# Altium schematic sheet MCU.SchDoc(MCU)
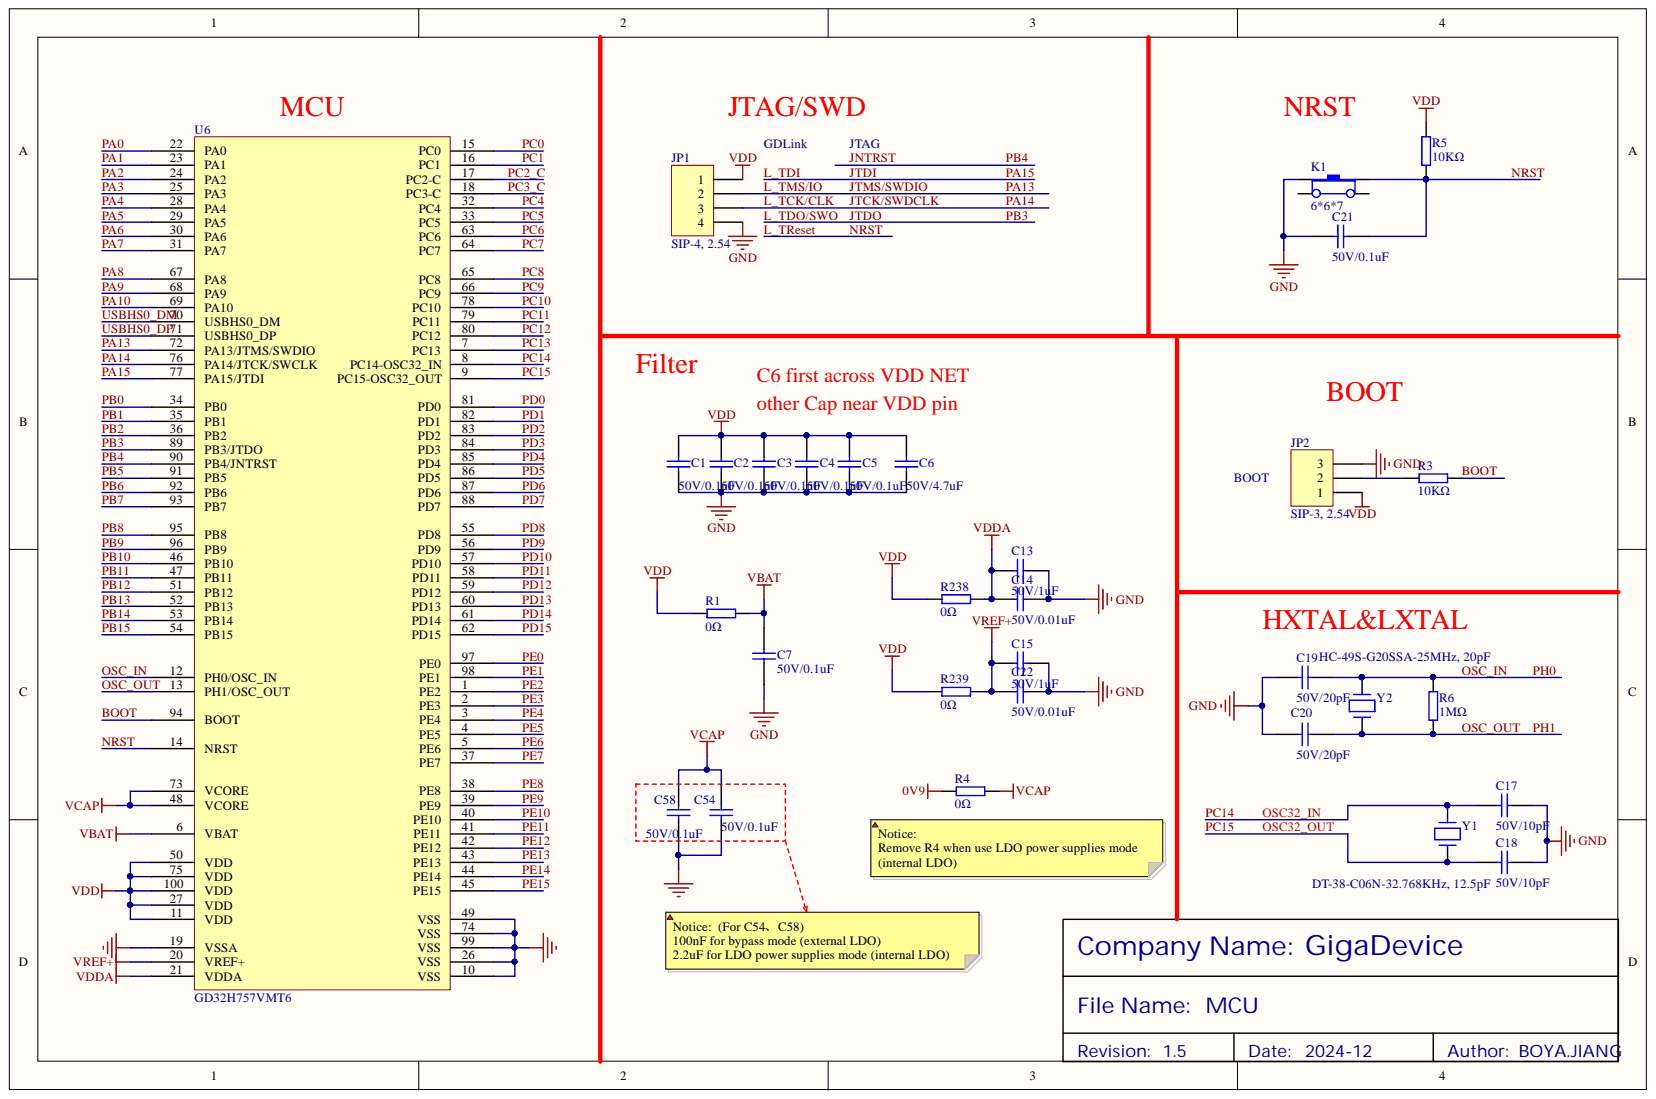
[diagram p0: MCU.SchDoc]

## Parts
C1  50V/0.1uF  [0603-C]
C2  50V/0.1uF  [0603-C]
C3  50V/0.1uF  [0603-C]
C4  50V/0.1uF  [0603-C]
C5  50V/0.1uF  [0603-C]
C6  50V/4.7uF  [0603-C]
C7  50V/0.1uF  [0603-C]
C13  50V/1uF  [0603-C]
C14  50V/0.01uF  [0603-C]
C15  50V/1uF  [0603-C]
C17  50V/10pF  [0603-C]
C18  50V/10pF  [0603-C]
C19  50V/20pF  [0603-C]
C20  50V/20pF  [0603-C]
C21  50V/0.1uF  [0603-C]
C22  50V/0.01uF  [0603-C]
C54  50V/0.1uF  [0603-C]
C58  50V/0.1uF  [0603-C]
JP1  SIP-4, 2.54  [SIP-4]
JP2  SIP-3, 2.54  [SIP-3]
K1  6*6*7  [K-1102B]
R1  0Ω  [0805-R]
R3  10KΩ  [0603-R]
R4  0Ω  [0805-R]
R5  10KΩ  [0603-R]
R6  1MΩ  [0603-R]
R238  0Ω  [0805-R]
R239  0Ω  [0805-R]
U6  GD32H757VMT6  [LQFP100_14x14x1.6]
Y1  DT-38-C06N-32.768KHz, 12.5pF  [DT-26]
Y2  HC-49S-G20SSA-25MHz, 20pF  [HC-49S]

## Nets
0V9: R4.1
GND: C1.1 C2.1 C3.1 C4.1 C5.1 C6.1 C7.2 C13.1 C14.1 C15.1 C17.2 C18.1 C19.1 C20.1 C21.2 C22.1 C54.2 C58.2 JP1.4 JP2.3 K1.1 U6.10(VSS) U6.19(VSSA) U6.26(VSS) U6.49(VSS) U6.74(VSS) U6.99(VSS)
VBAT: C7.1 R1.2 U6.6(VBAT)
VCAP: C54.1 C58.1 R4.2 U6.48(VCORE) U6.73(VCORE)
VDD: C1.2 C2.2 C3.2 C4.2 C5.2 C6.2 JP1.1 JP2.1 R1.1 R5.2 R238.1 R239.1 U6.11(VDD) U6.27(VDD) U6.50(VDD) U6.75(VDD) U6.100(VDD)
VDDA: C13.2 C14.2 R238.2 U6.21(VDDA)
VREF+: C15.2 C22.2 R239.2 U6.20(VREF+)
BOOT: R3.1 U6.94(BOOT)
JNTRST: U6.90(PB4/JNTRST)
JTCK/SWDCLK: JP1.3 U6.76(PA14/JTCK/SWCLK)
JTDI: U6.77(PA15/JTDI)
JTDO: U6.89(PB3/JTDO)
JTMS/SWDIO: JP1.2 U6.72(PA13/JTMS/SWDIO)
L_TReset: C21.1 K1.3 R5.1 U6.14(NRST)
OSC_IN: C19.2 R6.2 U6.12(PH0/OSC_IN) Y2.2
OSC_OUT: C20.2 R6.1 U6.13(PH1/OSC_OUT) Y2.1
PA0: U6.22(PA0)
PA1: U6.23(PA1)
PA2: U6.24(PA2)
PA3: U6.25(PA3)
PA4: U6.28(PA4)
PA5: U6.29(PA5)
PA6: U6.30(PA6)
PA7: U6.31(PA7)
PA8: U6.67(PA8)
PA9: U6.68(PA9)
PA10: U6.69(PA10)
PB0: U6.34(PB0)
PB1: U6.35(PB1)
PB2: U6.36(PB2)
PB5: U6.91(PB5)
PB6: U6.92(PB6)
PB7: U6.93(PB7)
PB8: U6.95(PB8)
PB9: U6.96(PB9)
PB10: U6.46(PB10)
PB11: U6.47(PB11)
PB12: U6.51(PB12)
PB13: U6.52(PB13)
PB14: U6.53(PB14)
PB15: U6.54(PB15)
PC0: U6.15(PC0)
PC1: U6.16(PC1)
PC2_C: U6.17(PC2-C)
PC3_C: U6.18(PC3-C)
PC4: U6.32(PC4)
PC5: U6.33(PC5)
PC6: U6.63(PC6)
PC7: U6.64(PC7)
PC8: U6.65(PC8)
PC9: U6.66(PC9)
PC10: U6.78(PC10)
PC11: U6.79(PC11)
PC12: U6.80(PC12)
PC13: U6.7(PC13)
PC14: C17.1 U6.8(PC14-OSC32_IN) Y1.2
PC15: C18.2 U6.9(PC15-OSC32_OUT) Y1.1
PD0: U6.81(PD0)
PD1: U6.82(PD1)
PD2: U6.83(PD2)
PD3: U6.84(PD3)
PD4: U6.85(PD4)
PD5: U6.86(PD5)
PD6: U6.87(PD6)
PD7: U6.88(PD7)
PD8: U6.55(PD8)
PD9: U6.56(PD9)
PD10: U6.57(PD10)
PD11: U6.58(PD11)
PD12: U6.59(PD12)
PD13: U6.60(PD13)
PD14: U6.61(PD14)
PD15: U6.62(PD15)
PE0: U6.97(PE0)
PE1: U6.98(PE1)
PE2: U6.1(PE2)
PE3: U6.2(PE3)
PE4: U6.3(PE4)
PE5: U6.4(PE5)
PE6: U6.5(PE6)
PE7: U6.37(PE7)
PE8: U6.38(PE8)
PE9: U6.39(PE9)
PE10: U6.40(PE10)
PE11: U6.41(PE11)
PE12: U6.42(PE12)
PE13: U6.43(PE13)
PE14: U6.44(PE14)
PE15: U6.45(PE15)
USBHS0_DM: U6.70(USBHS0_DM)
USBHS0_DP: U6.71(USBHS0_DP)
NetJP2_2: JP2.2 R3.2

Not connected: K1 2 4

## Library data of the parts
C1-C7 C13-C15 C21 C22 C54 C58 = 50V/0.1uF: 表贴电容; Component Kind: Standard; Library Name: KLTC-电容.SchLib
C17 C18 = 50V/10pF: 表贴电容; Component Kind: Standard; Library Name: KLTC-电容.SchLib
C19 C20 = 50V/20pF: 表贴电容; Component Kind: Standard; Library Name: KLTC-电容.SchLib
JP1 = HEADER 4: 单排插针; Component Kind: Standard; Library Name: KLTC-按键及接插件.SchLib
JP2 = HEADER 3: 单排插针; Component Kind: Standard; Library Name: KLTC-按键及接插件.SchLib
K1 = K-1102B: 普通6X6X7直插按键; Component Kind: Standard; Library Name: KLTC-按键及接插件.SchLib
R1 R4 R238 R239 = 0Ω: 表贴电阻; Library Name: GDM3203ZE-EVAL.IntLib; Component Kind: Standard
R3 R5 = 10KΩ: 表贴电阻; Component Kind: Standard; Library Name: KLTC-电阻.SchLib
R6 = 1MΩ: 表贴电阻; Component Kind: Standard; Library Name: KLTC-电阻.SchLib
U6 = GD32H7xxVxT6: 表贴MCU; Library Name: GD32.schlib; Component Kind: Standard
Y1 = DT-26-C06N-32.768KHz: 直插晶振; Component Kind: Standard; Library Name: KLTC-晶振.SchLib
Y2 = HC-49S-G20SSA-8MHz: 直插晶振; Component Kind: Standard; Library Name: KLTC-晶振.SchLib
